# Altium schematic sheet Gate_Drivers.SchDoc
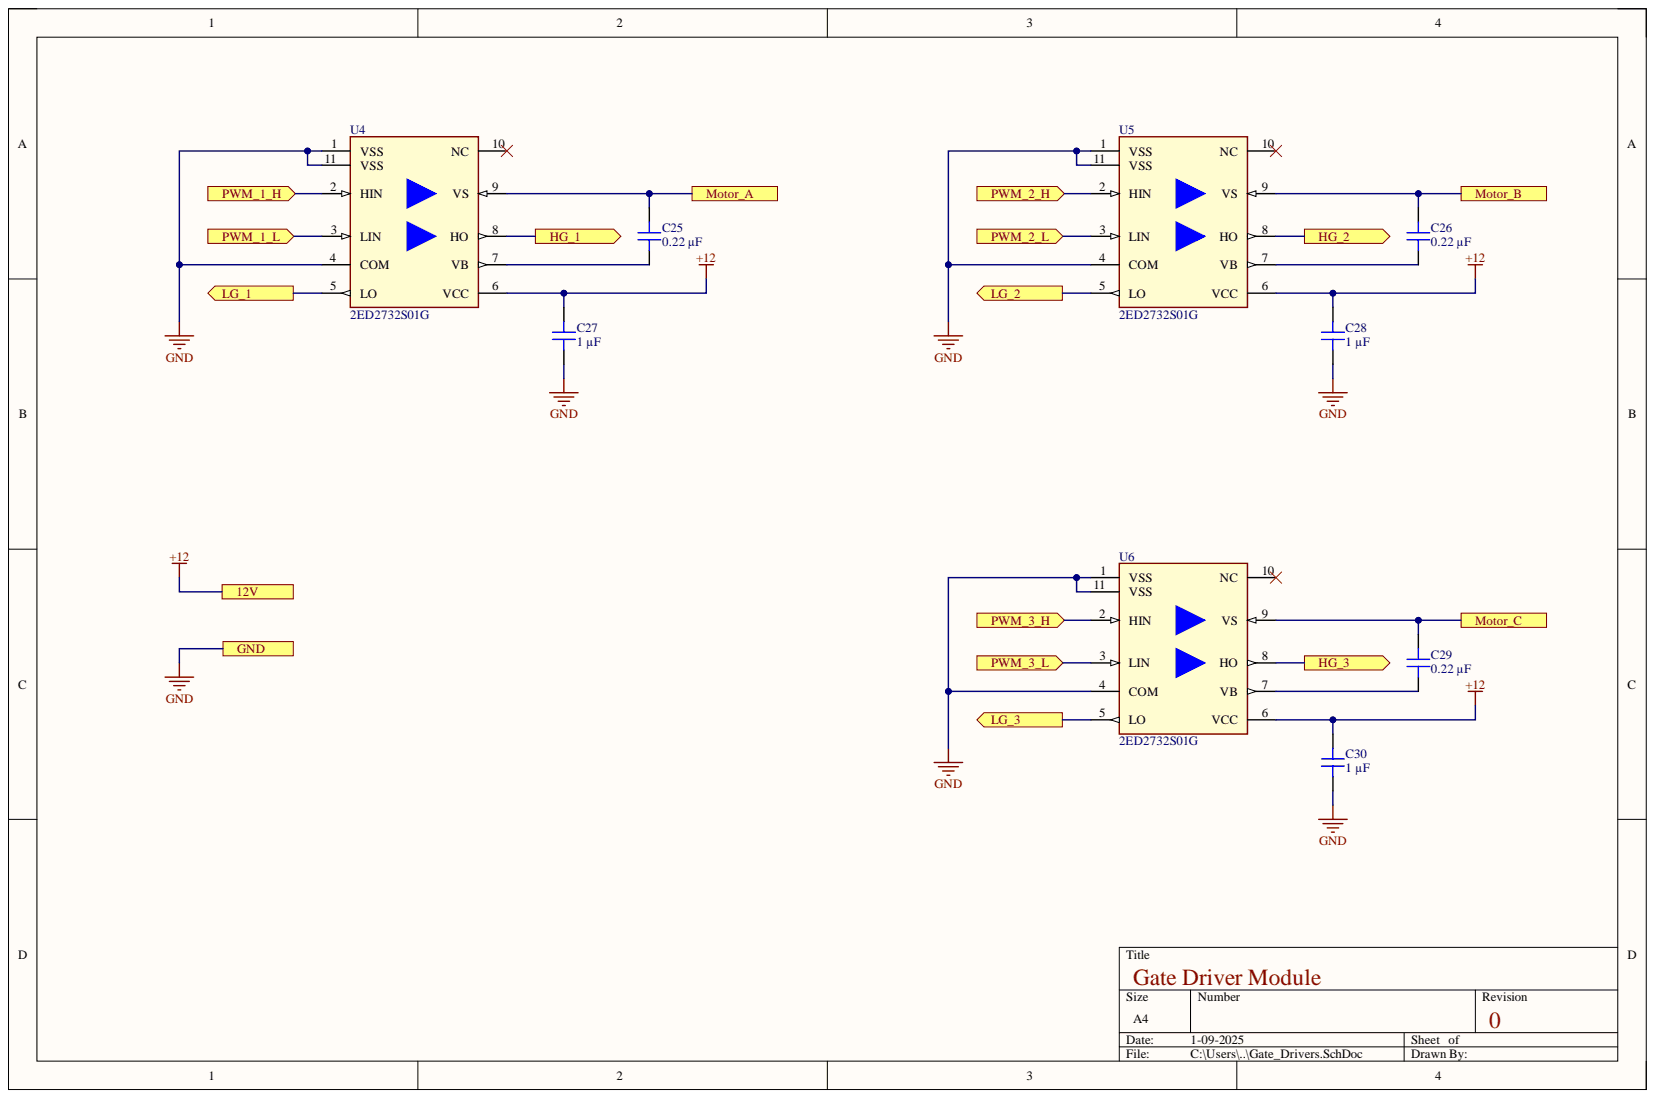
[diagram p0: Gate_Drivers.SchDoc]

## Parts
C25  0.22 µF  [CAP 0603_1608]
C26  0.22 µF  [CAP 0603_1608]
C27  1 µF  [MURATA GRM155 0402_1005]
C28  1 µF  [MURATA GRM155 0402_1005]
C29  0.22 µF  [CAP 0603_1608]
C30  1 µF  [MURATA GRM155 0402_1005]
U4  2ED2732S01G  [SON50P300X300X90-11N-1]
U5  2ED2732S01G  [SON50P300X300X90-11N-1]
U6  2ED2732S01G  [SON50P300X300X90-11N-1]

## Ports
12V, GND, HG_1, HG_2, HG_3, LG_1, LG_2, LG_3, Motor_A, Motor_B, Motor_C, PWM_1_H, PWM_1_L, PWM_2_H, PWM_2_L, PWM_3_H, PWM_3_L

## Nets
+12: C27.2 C28.2 C30.2 U4.6(VCC) U5.6(VCC) U6.6(VCC) ; port 12V
GND: C27.1 C28.1 C30.1 U4.1(VSS) U4.4(COM) U4.11(VSS) U5.1(VSS) U5.4(COM) U5.11(VSS) U6.1(VSS) U6.4(COM) U6.11(VSS) ; port GND
HG_1: U4.8(HO) ; port HG_1
HG_2: U5.8(HO) ; port HG_2
HG_3: U6.8(HO) ; port HG_3
LG_1: U4.5(LO) ; port LG_1
LG_2: U5.5(LO) ; port LG_2
LG_3: U6.5(LO) ; port LG_3
Motor_A: C25.2 U4.9(VS) ; port Motor_A
Motor_B: C26.2 U5.9(VS) ; port Motor_B
Motor_C: C29.2 U6.9(VS) ; port Motor_C
PWM_1_H: U4.2(HIN) ; port PWM_1_H
PWM_1_L: U4.3(LIN) ; port PWM_1_L
PWM_2_H: U5.2(HIN) ; port PWM_2_H
PWM_2_L: U5.3(LIN) ; port PWM_2_L
PWM_3_H: U6.2(HIN) ; port PWM_3_H
PWM_3_L: U6.3(LIN) ; port PWM_3_L
NetC25_1: C25.1 U4.7(VB)
NetC26_1: C26.1 U5.7(VB)
NetC29_1: C29.1 U6.7(VB)
NetU4_10: U4.10(NC)
NetU5_10: U5.10(NC)
NetU6_10: U6.10(NC)

## Library data of the parts
C25 C26 C29 = 885012206073: CAP CER 0.22UF 25V X7R 0603; manufacturer: Würth Elektronik; Database Table Name: Capacitors - Ceramic - 0603; Tolerance: ±10%; Applications: General Purpose; Capacitance: 0.22 µF; Component Kind: Standard; componentlink1description: Datasheet; componentlink1url: https://katalog.we-online.de/pbs/datasheet/885012206073.pdf; componentlink2description: DigiKey Link; componentlink2url: https://www.digikey.com/en/products/detail/würth-elektronik/885012206073/5453846; Device Package: 0603 (1608 Metric); lastupdated: 09-02-2024 20:10:05; Library Name: Celestial Altium Library - altium_library.DbLib; Lifecycle Status: Active; Minimum Order: 1; Mounting Type: Surface Mount, MLCC; Operating Temperature: -55°C ~ 125°C; Package / Case: 0603 (1608 Metric); packaging: TapeAndReel; Part Number: 885012206073; Part Status: Active; partid: 18125; price: 0.05; series: WCAP-CSGP; Size / Dimension: 0.063" L x 0.031" W (1.60mm x 0.80mm); Supplier 1: DigiKey; Supplier Part Number 1: 732-7991-1-ND; Temperature Coefficient: X7R; Thickness (Max): 0.037" (0.95mm); Value: 0.22 µF; Voltage - Rated: 25V
C27 C28 C30 = GRM155R61E105KA12D: CAP CER 1UF 25V X5R 0402; manufacturer: Murata Electronics; Database Table Name: Capacitors - Ceramic - 0402; Tolerance: ±10%; Applications: General Purpose; Capacitance: 1 µF; Component Kind: Standard; componentlink1description: Datasheet; componentlink1url: https://search.murata.co.jp/Ceramy/image/img/A01X/G101/ENG/GRM155R61E105KA12-01.pdf; componentlink2description: DigiKey Link; componentlink2url: https://www.digikey.com/en/products/detail/murata-electronics/GRM155R61E105KA12D/4905139; Device Package: 0402 (1005 Metric); lastupdated: 27-08-2024 12:42:53; Library Name: Celestial Altium Library - altium_library.DbLib; Lifecycle Status: Active; Minimum Order: 1; Mounting Type: Surface Mount, MLCC; Operating Temperature: -55°C ~ 85°C; Package / Case: 0402 (1005 Metric); packaging: TapeAndReel; Part Number: GRM155R61E105KA12D; Part Status: Active; partid: 5697; price: 0.01; series: GRM; Size / Dimension: 0.039" L x 0.020" W (1.00mm x 0.50mm); Supplier 1: DigiKey; Supplier Part Number 1: 490-10017-1-ND; Temperature Coefficient: X5R; Thickness (Max): 0.022" (0.55mm); Value: 1 µF; Voltage - Rated: 25V
U4-U6 = CMP-372-000000-3: Half Bridge SOI Gate Driver with Integrated Bootstrap Diode; Manufacturer: Infineon Technologies; Component Kind: Standard; Design Item ID: 2ED2732S01G; Library Name: CSD Robocon Workspace; Manufacturer Order Number: 2ED2732S01G; PackageReference: PG-VSON-10-5; Source: 2ED2732S01G.SchLib
